# Altium schematic sheet SparkBOB.SchDoc(SparkBOB)
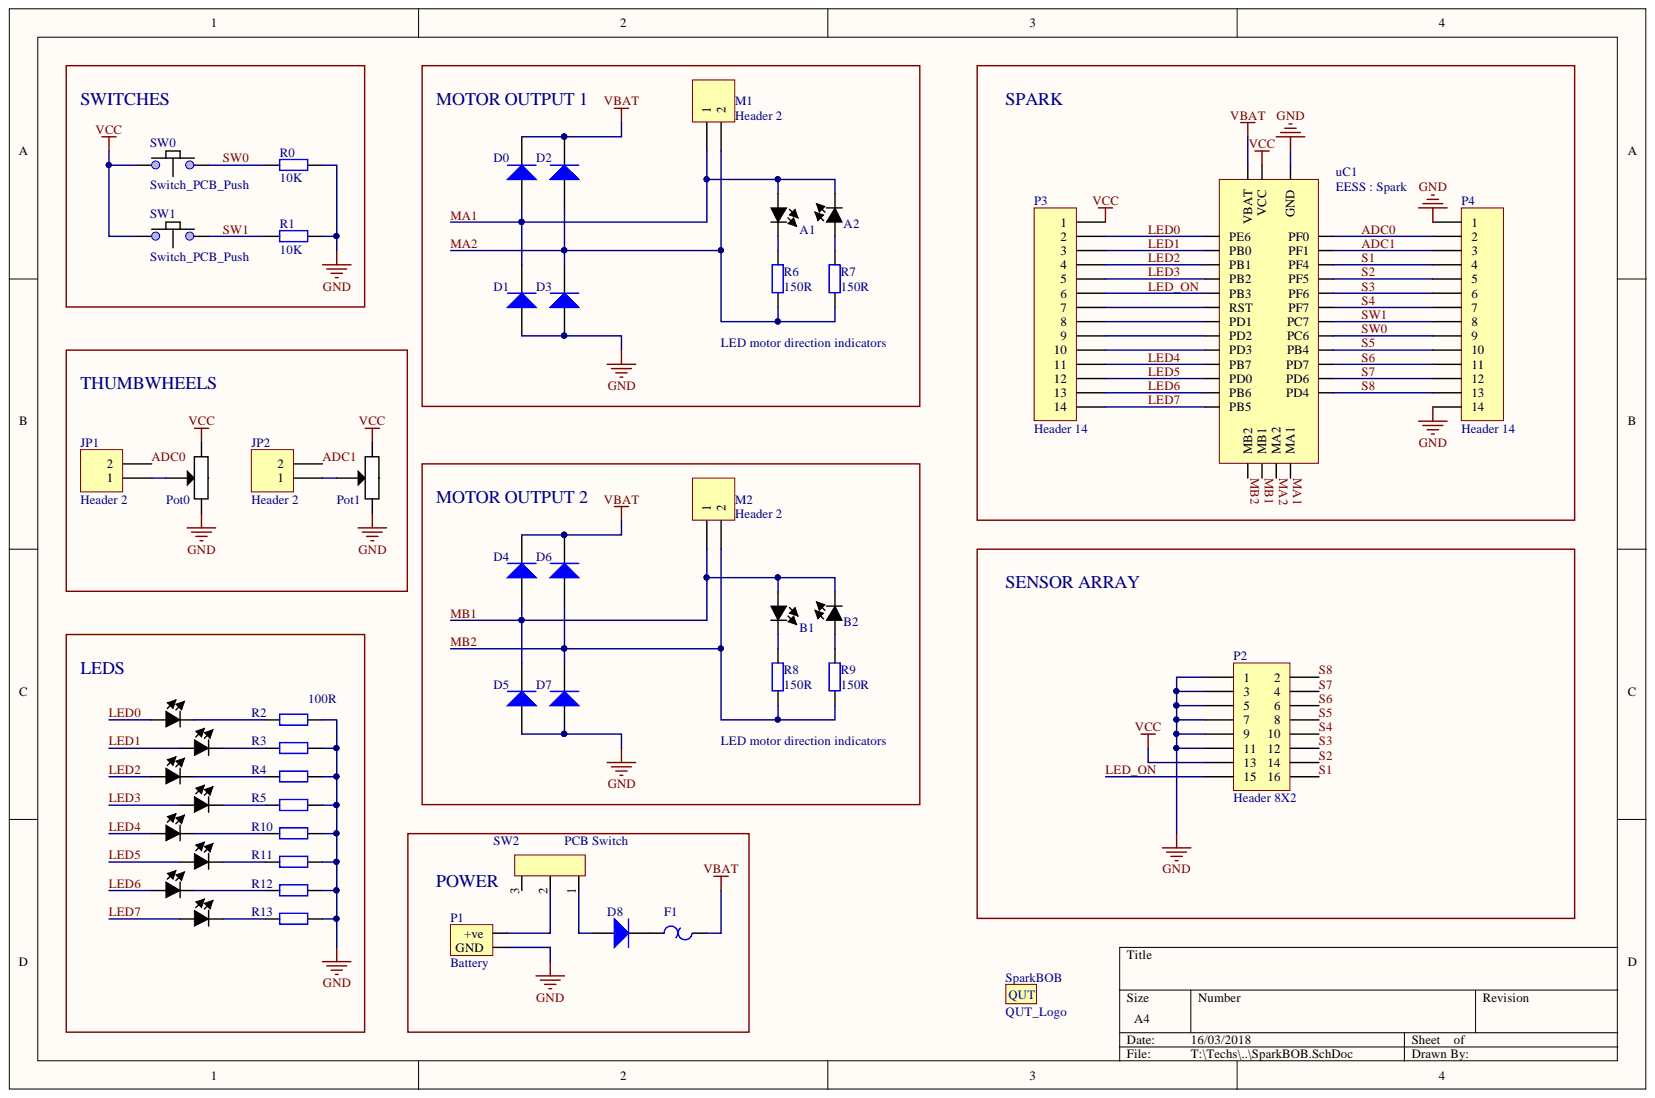
[diagram p0: SparkBOB.SchDoc]

## Parts
A1  LED*  [6-0805_M]  (value not drawn)
A2  LED*  [6-0805_M]  (value not drawn)
B1  LED*  [6-0805_M]  (value not drawn)
B2  LED*  [6-0805_M]  (value not drawn)
D0  (no value)  [SMB]
D1  (no value)  [SMB]
D2  (no value)  [SMB]
D3  (no value)  [SMB]
D4  (no value)  [SMB]
D5  (no value)  [SMB]
D6  (no value)  [SMB]
D7  (no value)  [SMB]
D8  SMD DIODE  [SODFL470X110-2N]  (value not drawn)
F1  RGEF250  [MF-MSMF250/16X-2]  (value not drawn)
JP1  Header 2  [HDR1X2]
JP2  Header 2  [HDR1X2]
LED0  LED*  [6-0805_M]
LED1  LED*  [6-0805_M]  (value not drawn)
LED2  LED*  [6-0805_M]
LED3  LED*  [6-0805_M]  (value not drawn)
LED4  LED*  [6-0805_M]
LED5  LED*  [6-0805_M]  (value not drawn)
LED6  LED*  [6-0805_M]
LED7  LED*  [6-0805_M]  (value not drawn)
M1  Header 2  [HDR1X2]
M2  Header 2  [HDR1X2]
P1  Battery  [XT30 - PCB mount]
P2  Header 8X2  [HDR2X8]
P3  Header 14  [HDR1X14]
P4  Header 14  [HDR1X14]
Pot0  Pot  [Bourns 3352T-1-103LF]
Pot1  Pot  [Bourns 3352T-1-103LF]
R0  value 10K  [6-0805_M]  (hidden comment: Res2)
R1  value 10K  [6-0805_M]  (hidden comment: Res2)
R2  value 100R  [6-0805_M]  (hidden comment: Res2)
R3  Res2  [6-0805_M]  (value not drawn)
R4  Res2  [6-0805_M]  (value not drawn)
R5  Res2  [6-0805_M]  (value not drawn)
R6  value 150R  [6-0805_M]  (hidden comment: Res2)
R7  value 150R  [6-0805_M]  (hidden comment: Res2)
R8  value 150R  [6-0805_M]  (hidden comment: Res2)
R9  value 150R  [6-0805_M]  (hidden comment: Res2)
R10  Res2  [6-0805_M]  (value not drawn)
R11  Res2  [6-0805_M]  (value not drawn)
R12  Res2  [6-0805_M]  (value not drawn)
R13  Res2  [6-0805_M]  (value not drawn)
SparkBOB  QUT_Logo  [QUT_Logo]
SW0  Switch_PCB_Push  [SMD 4 contact switch]
SW1  Switch_PCB_Push  [SMD 4 contact switch]
SW2  PCB Switch  [PCB Switch]
uC1  EESS : Spark  [EESS : Spark]

## Nets
GND: D1.1 D3.1 D5.1 D7.1 P1.1 P2.1 P2.3 P2.5 P2.7 P2.9 P2.11 P4.1 P4.14 Pot0.1 Pot1.1 R0.1 R1.1 R2.2 R3.2 R4.2 R5.2 R10.2 R11.2 R12.2 R13.2 uC1.GND
VBAT: D0.2 D2.2 D4.2 D6.2 F1.2 uC1.VBAT
VCC: P2.13 P3.1 Pot0.3 Pot1.3 SW0.0 SW1.0 uC1.VCC
ADC0: JP1.2 P4.2 uC1.PF0
ADC1: JP2.2 P4.3 uC1.PF1
LED0: LED0.1 P3.2 uC1.PE6
LED1: LED1.1 P3.3 uC1.PB0
LED2: LED2.1 P3.4 uC1.PB1
LED3: LED3.1 P3.5 uC1.PB2
LED4: LED4.1 P3.11 uC1.PB7
LED5: LED5.1 P3.12 uC1.PD0
LED6: LED6.1 P3.13 uC1.PB6
LED7: LED7.1 P3.14 uC1.PB5
LED_ON: P2.15 P3.6 uC1.PB3
MA1: A1.1 A2.2 D0.1 D1.2 M1.1 uC1.MA1
MA2: D2.1 D3.2 M1.2 R6.2 R7.2 uC1.MA2
MB1: B1.1 B2.2 D4.1 D5.2 M2.1 uC1.MB1
MB2: D6.1 D7.2 M2.2 R8.2 R9.2 uC1.MB2
S1: P2.16 P4.4 uC1.PF4
S2: P2.14 P4.5 uC1.PF5
S3: P2.12 P4.6 uC1.PF6
S4: P2.10 P4.7 uC1.PF7
S5: P2.8 P4.10 uC1.PB4
S6: P2.6 P4.11 uC1.PD7
S7: P2.4 P4.12 uC1.PD6
S8: P2.2 P4.13 uC1.PD4
SW0: P4.9 R0.2 SW0.1 uC1.PC6
SW1: P4.8 R1.2 SW1.1 uC1.PC7
NetA1_2: A1.2 R6.1
NetA2_1: A2.1 R7.1
NetB1_2: B1.2 R8.1
NetB2_1: B2.1 R9.1
NetD8_1: D8.1 SW2.1
NetD8_2: D8.2 F1.1
NetJP1_1: JP1.1 Pot0.2
NetJP2_1: JP2.1 Pot1.2
NetLED0_2: LED0.2 R2.1
NetLED1_2: LED1.2 R3.1
NetLED2_2: LED2.2 R4.1
NetLED3_2: LED3.2 R5.1
NetLED4_2: LED4.2 R10.1
NetLED5_2: LED5.2 R11.1
NetLED6_2: LED6.2 R12.1
NetLED7_2: LED7.2 R13.1
NetP1_2: P1.2 SW2.2
NetP3_7: P3.7 uC1.RST
NetP3_8: P3.8 uC1.PD1
NetP3_9: P3.9 uC1.PD2
NetP3_10: P3.10 uC1.PD3

Not connected: SW2 3

## Library data of the parts
A1 A2 B1 B2 LED0-LED7 = LED: Component Kind: Standard; Supplier: E14 1716764; Library Name: QUT.IntLib
D0-D7 = Diode 1N4934: Code_JEDEC: DO-204-AL; Component Kind: Standard; ComponentLink1Description: Manufacturer Link; ComponentLink1URL: http://www.fairchildsemi.com/; ComponentLink2Description: Datasheet; ComponentLink2URL: http://www.fairchildsemi.com/ds/1N%2F1N4934.pdf; DatasheetVersion: 1999; LatestRevisionDate: 17-Jul-2002; LatestRevisionNote: Re-released for DXP Platform.; Library Name: Miscellaneous Devices.IntLib; PackageReference: DO-41; PackageVersion: Aug-1999; Published: 8-Jun-2000; Signal Integrity: 1N4934; Simulation: 1N4934; Supplier: E14 1459073; Publisher: Altium Limited
D8 = SMD DIODE: Surface mount Diode; Component Kind: Standard; Supplier: Digikey 1727-5317-1-ND; Library Name: Penguin.SchLib
F1 = RGEF250: PPTC Thermal Fuse; Hold Current: 2.5A; Trip Current: 4.7A; VRating: 16V; Component Kind: Standard; Supplier: E14 2519587; Library Name: Penguin.SchLib
JP1 JP2 M1 M2 = Header 2: Header, 2-Pin; Component Kind: Standard; LatestRevisionDate: 17-Jul-2002; LatestRevisionNote: Re-released for DXP Platform.; Library Name: Miscellaneous Connectors.IntLib; Signal Integrity: Connector; Publisher: Altium Limited
P1 = XT30: Component Kind: Standard; Library Name: QUT.IntLib
P2 = Header 8X2: Header, 8-Pin, Dual row; Component Kind: Standard; LatestRevisionDate: 17-Jul-2002; LatestRevisionNote: Re-released for DXP Platform.; Library Name: Miscellaneous Connectors.IntLib; Signal Integrity: Connector; Publisher: Altium Limited
P3 P4 = Header 14: Header, 14-Pin; Component Kind: Standard; LatestRevisionDate: 17-Jul-2002; LatestRevisionNote: Re-released for DXP Platform.; Library Name: Miscellaneous Connectors.IntLib; Signal Integrity: Connector; Publisher: Altium Limited
R0-R2 R6-R9 = Res2: Resistor; Component Kind: Standard; LatestRevisionDate: 17-Jul-2002; LatestRevisionNote: Re-released for DXP Platform.; Library Name: Miscellaneous Devices.IntLib; PackageReference: AXIAL-0.4; Published: 8-Jun-2000; Signal Integrity: Res; Simulation: RESISTOR; Publisher: Altium Limited
R3-R5 R10-R13 = Res2: Resistor; Value: 100R; Component Kind: Standard; LatestRevisionDate: 17-Jul-2002; LatestRevisionNote: Re-released for DXP Platform.; Library Name: Miscellaneous Devices.IntLib; PackageReference: AXIAL-0.4; Published: 8-Jun-2000; Signal Integrity: Res; Simulation: RESISTOR; Publisher: Altium Limited
Pot0 Pot1 = Pot: Component Kind: Standard; Supplier: Digikey 3352T-103LF-ND; Library Name: QUT.IntLib
SparkBOB = QUT_Logo: Component Kind: Standard; Library Name: QUT.IntLib
SW0 SW1 = Switch_PCB_Push: Component Kind: Standard; Supplier: E14 1570392; Library Name: QUT.IntLib
SW2 = PCB Switch: Component Kind: Standard; Supplier: Digikey CKN5005-ND; Library Name: PenguinPi_v2.SchLib
uC1 = EESS : Spark: Component Kind: Standard; Library Name: QUT.IntLib
